# Altium schematic sheet optical_v1.1.SchDoc(optical_v1.1)
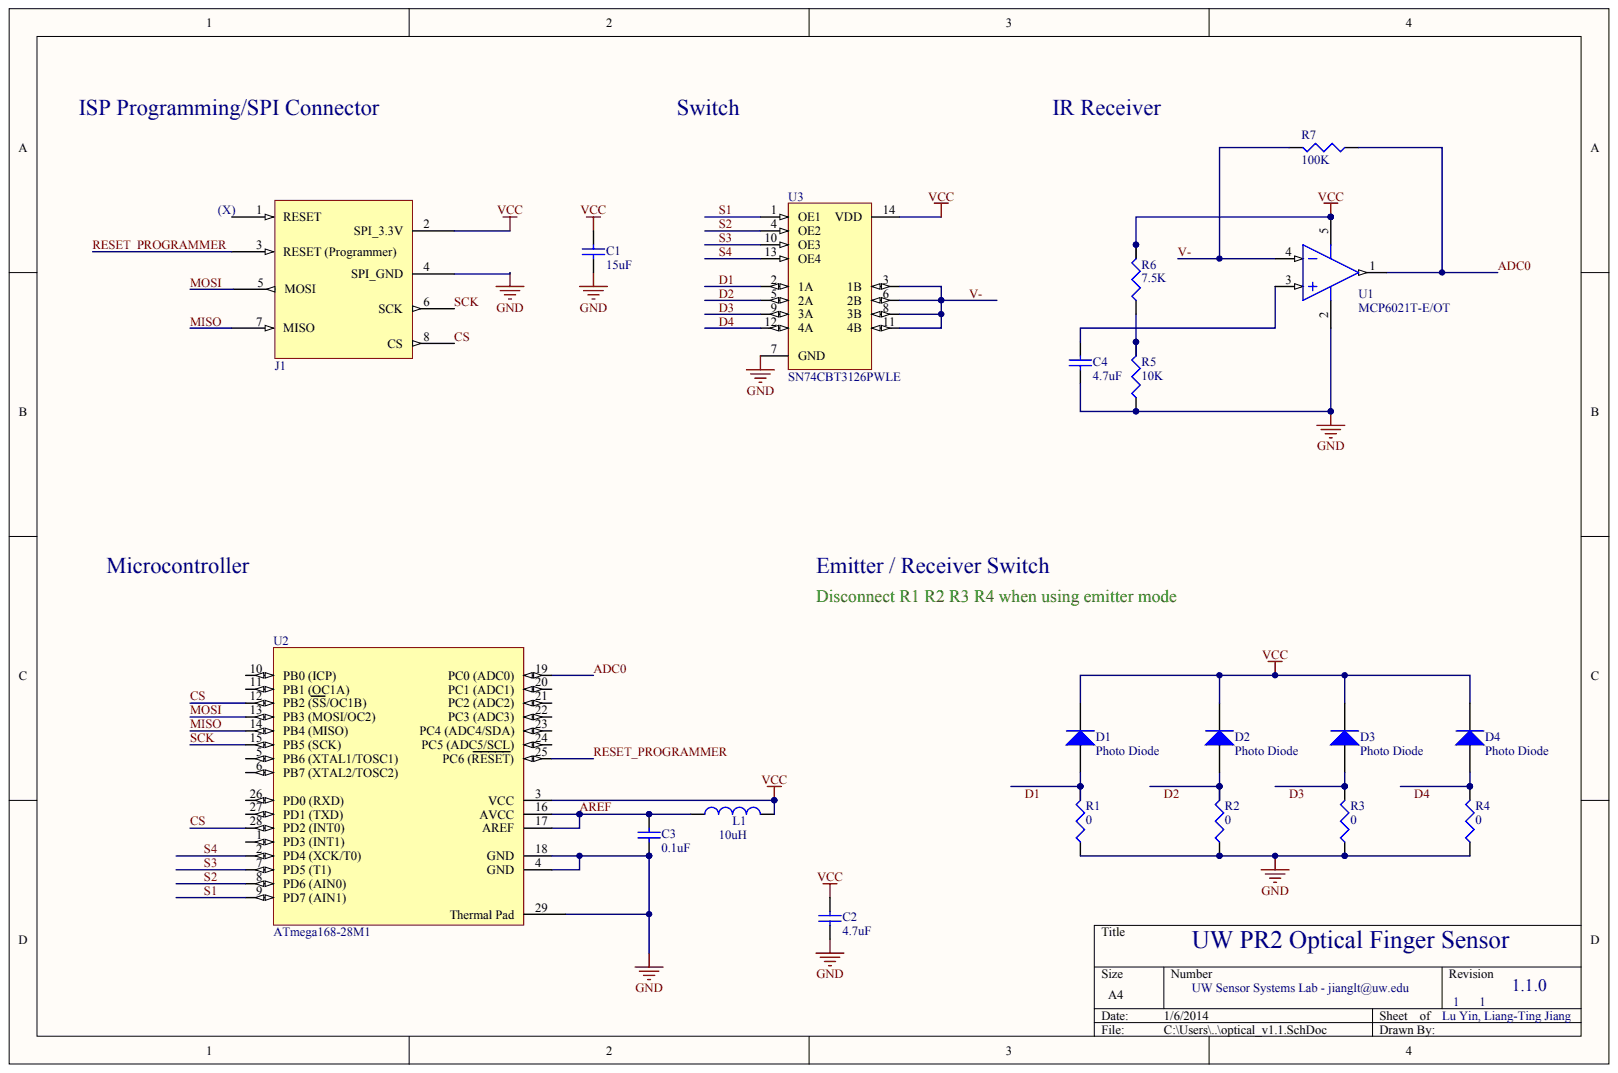
[diagram p0: optical_v1.1.SchDoc]

## Parts
C1  value 15uF  (hidden comment: Cap Semi)
C2  value 4.7uF  (hidden comment: Cap Semi)
C3  value 0.1uF  (hidden comment: BypassCap)
C4  value 4.7uF  (hidden comment: Cap Semi)
D1  Photo Diode
D2  Photo Diode
D3  Photo Diode
D4  Photo Diode
J1  FFC 8-PIN Connector (1mm)  (value not drawn)
L1  value 10uH  (hidden comment: Inductor)
R1  value 0  (hidden comment: Rlevel)
R2  value 0  (hidden comment: Rlevel)
R3  value 0  (hidden comment: Rlevel)
R4  value 0  (hidden comment: Rlevel)
R5  value 10K  (hidden comment: Rlevel)
R6  value 7.5K  (hidden comment: Rlevel)
R7  value 100K  (hidden comment: Rlevel)
U1  MCP6021T-E/OT
U2  ATmega168-28M1
U3  SN74CBT3126PWLE

## Nets
GND: C1.2 C2.2 C3.1 C4.2 J1.4(SPI_GND) R1.1 R2.1 R3.1 R4.1 R5.1 U1.2 U2.4(GND) U2.18(GND) U2.29(Thermal_Pad) U3.7(GND)
VCC: C1.1 C2.1 D1.2 D2.2 D3.2 D4.2 J1.2(SPI_3.3V) L1.2 R6.2 U1.5 U2.3(VCC) U3.14(VDD)
ADC0: R7.1 U1.1 U2.19(PC0_(ADC0))
AREF: C3.2 L1.1 U2.16(AVCC) U2.17(AREF)
CS: J1.8(CS) U2.12(PB2_(SS/OC1B)) U2.28(PD2_(INT0))
D1: U3.2(1A)
D2: U3.5(2A)
D3: U3.9(3A)
D4: U3.12(4A)
MISO: J1.7(MISO) U2.14(PB4_(MISO))
MOSI: J1.5(MOSI) U2.13(PB3_(MOSI/OC2))
RESET_PROGRAMMER: J1.3(RESET_(Programmer)) U2.25(PC6_(RESET))
S1: U2.9(PD7_(AIN1)) U3.1(OE1)
S2: U2.8(PD6_(AIN0)) U3.4(OE2)
S3: U2.7(PD5_(T1)) U3.10(OE3)
S4: U2.2(PD4_(XCK/T0)) U3.13(OE4)
SCK: J1.6(SCK) U2.15(PB5_(SCK))
V-: R7.2 U1.4 U3.3(1B) U3.6(2B) U3.8(3B) U3.11(4B)
NetC4_1: C4.1 U1.3
NetD1_1: D1.1 R1.2
NetD2_1: D2.1 R2.2
NetD3_1: D3.1 R3.2
NetD4_1: D4.1 R4.2
NetR5_2: R5.2 R6.1

Not connected: J1 1(RESET); U2 1(PD3_(INT1)) 5(PB6_(XTAL1/TOSC1)) 6(PB7_(XTAL2/TOSC2)) 10(PB0_(ICP)) 11(PB1_(OC1A)) 20(PC1_(ADC1)) 21(PC2_(ADC2)) 22(PC3_(ADC3)) 23(PC4_(ADC4/SDA)) 24(PC5_(ADC5/SCL)) 26(PD0_(RXD)) 27(PD1_(TXD))

## Library data of the parts
L1 = (no symbol name): Code_IEC: 1005; Published: 8-Jun-2000; LatestRevisionDate: 29-May-2009; LatestRevisionNote: IPC-7351 Footprint Added.; PackageReference: 0402-A; PackageDescription: Chip Inductor; Code_JEITA: 0402; Publisher: Altium Limited
C1-C4 D1-D4 J1 R1-R7 U1-U3 = (no symbol name)
  C1-C4: Published: 8-Jun-2000; LatestRevisionDate: 29-May-2009; LatestRevisionNote: IPC-7351 Footprint Added.; PackageReference: C1206; PackageDescription: Chip Capacitor
  D1-D4: Published: 8-Jun-2000; LatestRevisionDate: 17-Jul-2002; LatestRevisionNote: Re-released for DXP Platform.; PackageVersion: Sep-1996; PackageReference: SMC; PackageDescription: DO-214-AB/SMC; 2 C-Bend Leads; Body 7.9 x 5.9 mm, inc. leads (LxW); Code_JEDEC: DO-214-AB
  R1-R7: Published: 8-Jun-2000; LatestRevisionDate: 29-May-2009; LatestRevisionNote: IPC-7351 Footprint Added.; PackageReference: J1-0603; PackageDescription: Chip Resistor
  U1: Published: 29-Jan-2009; LatestRevisionDate: 02-Dec-2009; LatestRevisionNote: Updated to datasheet revision D, Feb-2009; DatasheetVersion: revD, Feb-2009; PackageVersion: revBB, Aug-2009; PackageReference: SOT-23-OT5; PackageDescription: 5-Lead Plastic Small Outline Transistor (OT) [SOT-23]; Supplier 1: Digi-Key; Supplier Part Number 1: MCP6021T-E/OTTR-ND; Supplier 2: Digi-Key; Supplier Part Number 2: MCP6021T-E/OTCT-ND; Supplier 3: Digi-Key; Supplier Part Number 3: MCP6021T-E/OTDKR-ND; Supplier 4: Farnell; Supplier Part Number 4: 1605573; Code_IPC: SOT23-5; ComponentLink1Description: Datasheet; ComponentLink1URL: http://ww1.microchip.com/downloads/en/DeviceDoc/21685d.pdf; ComponentLink2Description: Packaging Specification; ComponentLink2URL: http://www.microchip.com/stellent/groups/techpub_sg/documents/packagingspec/en012702.pdf; ComponentLink3Description: Microchip.com Home; ComponentLink3URL: http://www.microchip.com/
  U2: Published: 17-Sep-2003; LatestRevisionDate: 04-Mar-2005; LatestRevisionNote: Stylized 3D Model Added.; DatasheetVersion: 2486H-AVR-09/02; PackageVersion: revB, Sep-2001; PackageReference: 28P3; PackageDescription: DIP; 28 Leads; Row Spacing 7.62 mm; Pitch 2.54 mm; ComponentLink1Description: Manufacturer Link; ComponentLink1URL: http://www.atmel.com; ComponentLink2Description: Datasheet; ComponentLink2URL: http://www.atmel.com/dyn/resources/prod_documents/doc2486.pdf
  U3: Published: 8-Jun-2000; LatestRevisionDate: 16-Oct-2006; LatestRevisionNote: IPC-7351 Footprint Added.; DatasheetVersion: May-1998; PackageVersion: Feb-1999; PackageReference: PW014; PackageDescription: 14-Pin Thin Small Outline Package 0.65 mm Pitch; ComponentLink1Description: Manufacturer Link; ComponentLink1URL: http://www.ti.com/; ComponentLink2Description: Datasheet; ComponentLink2URL: http://www-s.ti.com/sc/ds/sn74cbt3126.pdf
  C1-C4 D1-D4 R1-R7 U1-U3: Publisher: Altium Limited
